# Altium schematic sheet 06 - STM32.SchDoc
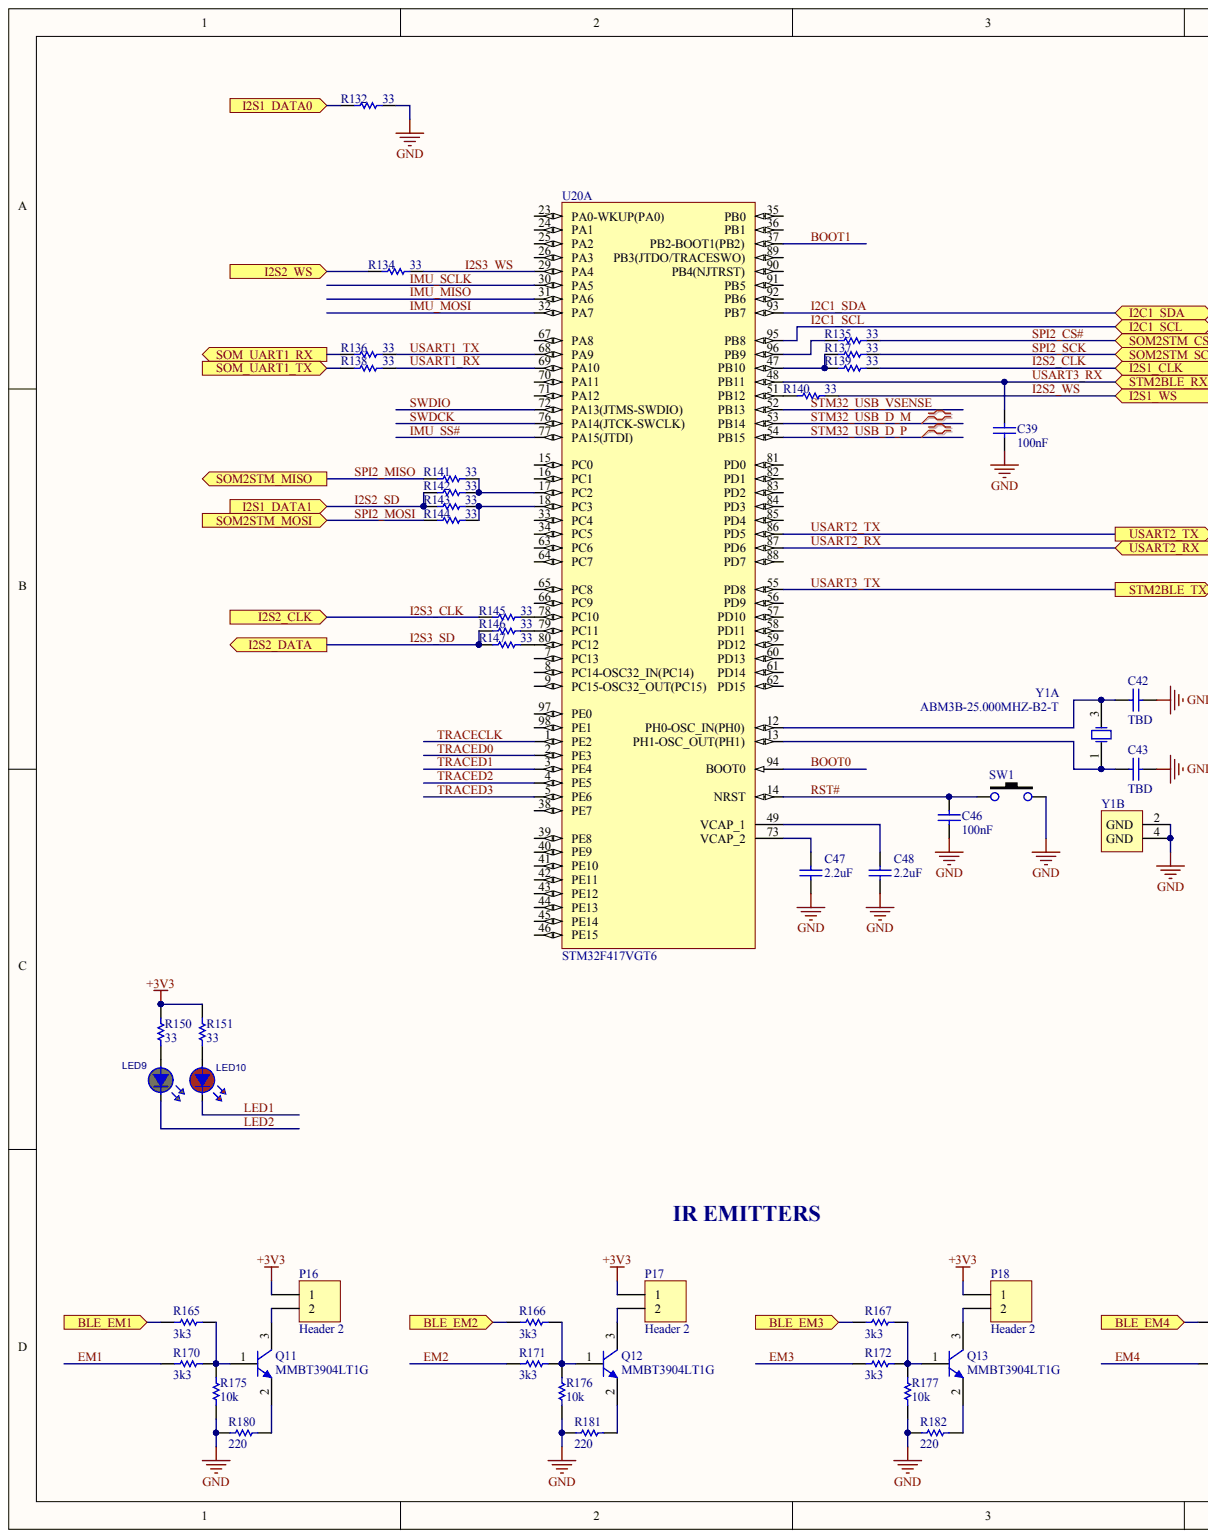
[diagram p0: 06 - STM32.SchDoc, left]
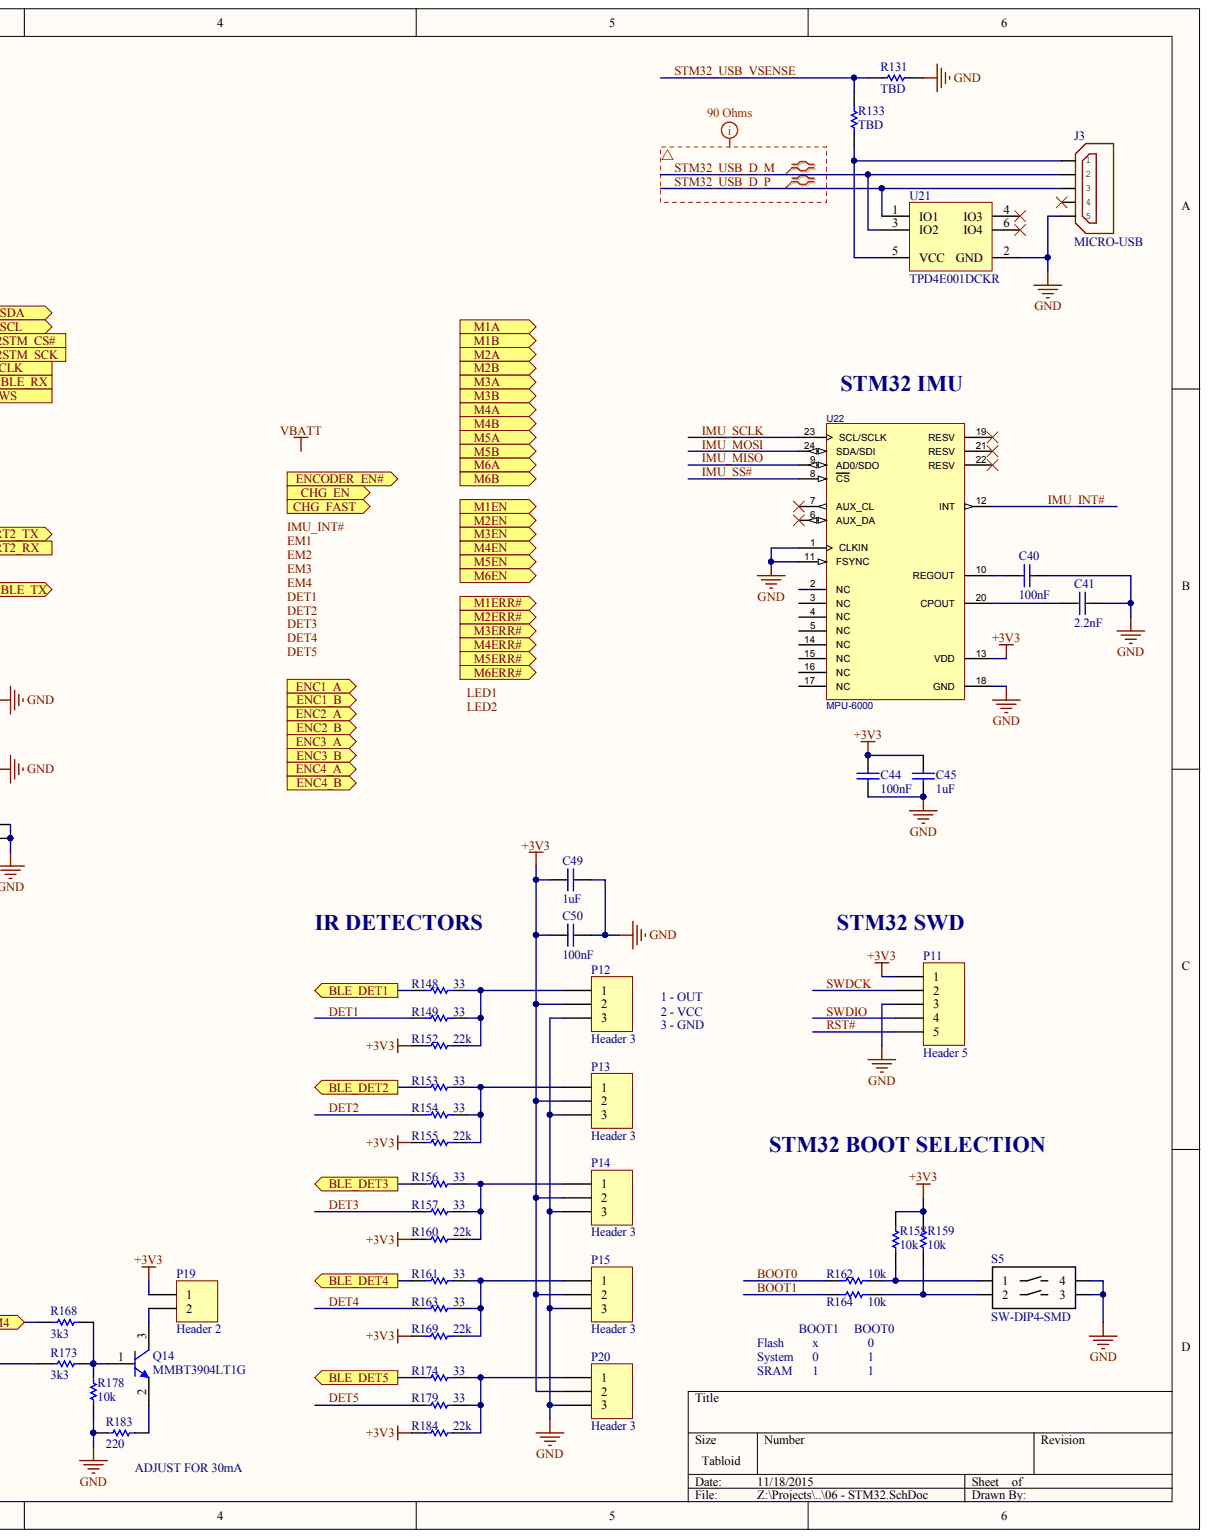
[diagram p1: 06 - STM32.SchDoc, right]

## Parts
C39  value 100nF  [CAPC0805(2012)100_N]  (hidden comment: CAP 100nF 6.3V 0805(2012))
C40  value 100nF  [CAPC0805(2012)100_N]  (hidden comment: CAP 100nF 6.3V 0805(2012))
C41  value 2.2nF  [CAPC0805(2012)100_L]
C42  value TBD  [CAPC0805(2012)100_N]
C43  value TBD  [CAPC0805(2012)100_N]
C44  value 100nF  [CAPC0805(2012)100_N]  (hidden comment: CAP 100nF 6.3V 0805(2012))
C45  value 1uF  [CAPC0805(2012)100_M]  (hidden comment: CAP 1uF 6.3V 0805(2012))
C46  value 100nF  [CAPC0805(2012)100_N]  (hidden comment: CAP 100nF 6.3V 0805(2012))
C47  value 2.2uF  [CAPC0805(2012)100_M]  (hidden comment: CAP 2.2uF 6.3V 0805(2012))
C48  value 2.2uF  [CAPC0805(2012)100_M]  (hidden comment: CAP 2.2uF 6.3V 0805(2012))
C49  value 1uF  [CAPC0805(2012)100_M]  (hidden comment: CAP 1uF 6.3V 0805(2012))
C50  value 100nF  [CAPC0805(2012)100_N]  (hidden comment: CAP 100nF 6.3V 0805(2012))
J3  MICRO-USB  [USB-MICRO]
LED9  VLMG1500-GS08  [LEDC0402(1005)_N-GRN]  (value not drawn)
LED10  VLMS1500-GS08  [LEDC0402(1005)_N-RED]  (value not drawn)
P11  Header 5  [HDR1X5]
P12  Header 3  [HDR1X3]
P13  Header 3  [HDR1X3]
P14  Header 3  [HDR1X3]
P15  Header 3  [HDR1X3]
P16  Header 2  [HDR1X2]
P17  Header 2  [HDR1X2]
P18  Header 2  [HDR1X2]
P19  Header 2  [HDR1X2]
P20  Header 3  [HDR1X3]
Q11  MMBT3904LT1G  [ONSC-SOT-23-3-318-08_V]
Q12  MMBT3904LT1G  [ONSC-SOT-23-3-318-08_V]
Q13  MMBT3904LT1G  [ONSC-SOT-23-3-318-08_V]
Q14  MMBT3904LT1G  [ONSC-SOT-23-3-318-08_V]
R131  value TBD  [RESC0805(2012)_M]
R132  value 33  [RESC0805(2012)_M]
R133  value TBD  [RESC0805(2012)_M]
R134  value 33  [RESC0805(2012)_M]
R135  value 33  [RESC0805(2012)_M]
R136  value 33  [RESC0805(2012)_M]
R137  value 33  [RESC0805(2012)_M]
R138  value 33  [RESC0805(2012)_M]
R139  value 33  [RESC0805(2012)_M]
R140  value 33  [RESC0805(2012)_M]
R141  value 33  [RESC0805(2012)_M]
R142  value 33  [RESC0805(2012)_M]
R143  value 33  [RESC0805(2012)_M]
R144  value 33  [RESC0805(2012)_M]
R145  value 33  [RESC0805(2012)_M]
R146  value 33  [RESC0805(2012)_M]
R147  value 33  [RESC0805(2012)_M]
R148  value 33  [RESC0805(2012)_M]
R149  value 33  [RESC0805(2012)_M]
R150  value 33  [RESC0805(2012)_M]
R151  value 33  [RESC0805(2012)_M]
R152  value 22k  [RESC0805(2012)_N]  (hidden comment: 22K 1% 0805(2012))
R153  value 33  [RESC0805(2012)_M]
R154  value 33  [RESC0805(2012)_M]
R155  value 22k  [RESC0805(2012)_N]  (hidden comment: 22K 1% 0805(2012))
R156  value 33  [RESC0805(2012)_M]
R157  value 33  [RESC0805(2012)_M]
R158  value 10k  [RESC0805(2012)_M]  (hidden comment: 10K 1% 0805(2012))
R159  value 10k  [RESC0805(2012)_M]  (hidden comment: 10K 1% 0805(2012))
R160  value 22k  [RESC0805(2012)_N]  (hidden comment: 22K 1% 0805(2012))
R161  value 33  [RESC0805(2012)_M]
R162  value 10k  [RESC0805(2012)_M]  (hidden comment: 10K 1% 0805(2012))
R163  value 33  [RESC0805(2012)_M]
R164  value 10k  [RESC0805(2012)_M]  (hidden comment: 10K 1% 0805(2012))
R165  value 3k3  [RESC0805(2012)_L]  (hidden comment: 3K3 1% 0805(2012))
R166  value 3k3  [RESC0805(2012)_L]  (hidden comment: 3K3 1% 0805(2012))
R167  value 3k3  [RESC0805(2012)_L]  (hidden comment: 3K3 1% 0805(2012))
R168  value 3k3  [RESC0805(2012)_L]  (hidden comment: 3K3 1% 0805(2012))
R169  value 22k  [RESC0805(2012)_N]  (hidden comment: 22K 1% 0805(2012))
R170  value 3k3  [RESC0805(2012)_L]  (hidden comment: 3K3 1% 0805(2012))
R171  value 3k3  [RESC0805(2012)_L]  (hidden comment: 3K3 1% 0805(2012))
R172  value 3k3  [RESC0805(2012)_L]  (hidden comment: 3K3 1% 0805(2012))
R173  value 3k3  [RESC0805(2012)_L]  (hidden comment: 3K3 1% 0805(2012))
R174  value 33  [RESC0805(2012)_M]
R175  value 10k  [RESC0805(2012)_M]  (hidden comment: 10K 1% 0805(2012))
R176  value 10k  [RESC0805(2012)_M]  (hidden comment: 10K 1% 0805(2012))
R177  value 10k  [RESC0805(2012)_M]  (hidden comment: 10K 1% 0805(2012))
R178  value 10k  [RESC0805(2012)_M]  (hidden comment: 10K 1% 0805(2012))
R179  value 33  [RESC0805(2012)_M]
R180  value 220  [RESC0805(2012)_M]  (hidden comment: 220R 1% 0805(2012))
R181  value 220  [RESC0805(2012)_M]  (hidden comment: 220R 1% 0805(2012))
R182  value 220  [RESC0805(2012)_M]  (hidden comment: 220R 1% 0805(2012))
R183  value 220  [RESC0805(2012)_M]  (hidden comment: 220R 1% 0805(2012))
R184  value 22k  [RESC0805(2012)_N]  (hidden comment: 22K 1% 0805(2012))
S5  SW-DIP4-SMD  [SO4SW]
SW1  SW-PB6MM-SMD  [SW-PB-SMD]  (value not drawn)
U20  STM32F417VGT6  [STM-LQFP100_M]  (part A)
U21  TPD4E001DCKR  [DCK0006A_M]
U22  MPU-6000  [QFN-ML24_N_NOPAD]
Y1  ABM3B-25.000MHZ-B2-T  [ABRA-ABM3B-4_V]  (parts A,B)

## Ports
BLE_DET1, BLE_DET2, BLE_DET3, BLE_DET4, BLE_DET5, BLE_EM1, BLE_EM2, BLE_EM3, BLE_EM4, CHG_EN, CHG_FAST, ENC1_A, ENC1_B, ENC2_A, ENC2_B, ENC3_A, ENC3_B, ENC4_A, ENC4_B, ENCODER_EN#, I2C1_SCL, I2C1_SDA, I2S1_CLK, I2S1_DATA0, I2S1_DATA1, I2S1_WS, I2S2_CLK, I2S2_DATA, I2S2_WS, M1A, M1B, M1EN, M1ERR#, M2A, M2B, M2EN, M2ERR#, M3A, M3B, M3EN, M3ERR#, M4A, M4B, M4EN, M4ERR#, M5A, M5B, M5EN, M5ERR#, M6A, M6B, M6EN, M6ERR#, SOM2STM_CS#, SOM2STM_MISO, SOM2STM_MOSI, SOM2STM_SCK, SOM_UART1_RX, SOM_UART1_TX, STM2BLE_RX, STM2BLE_TX, USART2_RX, USART2_TX

## Nets
+3V3: C44.1 C45.1 C49.1 C50.1 P11.1 P12.2 P13.2 P14.2 P15.2 P16.1 P17.1 P18.1 P19.1 P20.2 R150.1 R151.1 R152.2 R155.2 R158.1 R159.1 R160.2 R169.2 R184.2 U22.13(VDD)
GND: C39.2 C40.2 C41.2 C42.2 C43.2 C44.2 C45.2 C46.2 C47.1 C48.1 C49.2 C50.2 J3.ID P11.3 P12.3 P13.3 P14.3 P15.3 P20.3 R131.2 R132.1 R175.1 R176.1 R177.1 R178.1 R180.2 R181.2 R182.2 R183.2 S5.3 S5.4 SW1.2 U21.2(GND) U22.1(CLKIN) U22.11(FSYNC) U22.18(GND) Y1.2(GND) Y1.4(GND)
BLE_DET1: R148.2 ; port BLE_DET1
BLE_DET2: R153.2 ; port BLE_DET2
BLE_DET3: R156.2 ; port BLE_DET3
BLE_DET4: R161.2 ; port BLE_DET4
BLE_DET5: R174.2 ; port BLE_DET5
BLE_EM1: R165.1 ; port BLE_EM1
BLE_EM2: R166.1 ; port BLE_EM2
BLE_EM3: R167.1 ; port BLE_EM3
BLE_EM4: R168.1 ; port BLE_EM4
BOOT0: R162.2 U20.94(BOOT0)
BOOT1: R164.2 U20.37(PB2-BOOT1(PB2))
DET1: R149.2
DET2: R154.2
DET3: R157.2
DET4: R163.2
DET5: R179.2
EM1: R170.1
EM2: R171.1
EM3: R172.1
EM4: R173.1
I2C1_SCL: U20.95(PB8) ; port I2C1_SCL
I2C1_SDA: U20.93(PB7) ; port I2C1_SDA
I2S1_DATA0: R132.2 ; port I2S1_DATA0
I2S2_CLK: R139.1 ; port I2S1_CLK
I2S2_SD: R142.2 R143.2 ; port I2S1_DATA1
I2S2_WS: R140.1 ; port I2S1_WS
I2S3_CLK: R145.2 ; port I2S2_CLK
I2S3_SD: R146.2 R147.2 ; port I2S2_DATA
I2S3_WS: R134.1 U20.29(PA4)
IMU_INT#: U22.12(INT)
IMU_MISO: U20.31(PA6) U22.9(AD0/SDO)
IMU_MOSI: U20.32(PA7) U22.24(SDA/SDI)
IMU_SCLK: U20.30(PA5) U22.23(SCL/SCLK)
IMU_SS#: U20.77(PA15(JTDI)) U22.8(CS)
LED1: LED10.K
LED2: LED9.K
RST#: C46.1 P11.5 SW1.1 U20.14(NRST)
SOM_UART1_RX: R136.2 ; port SOM_UART1_RX
SOM_UART1_TX: R138.2 ; port SOM_UART1_TX
SPI2_CS#: R135.1 ; port SOM2STM_CS#
SPI2_MISO: R141.2 ; port SOM2STM_MISO
SPI2_MOSI: R144.2 ; port SOM2STM_MOSI
SPI2_SCK: R137.1 ; port SOM2STM_SCK
STM32_USB_D_M: J3.D- U20.53(PB14) U21.3(IO2)
STM32_USB_D_P: J3.D+ U20.54(PB15) U21.1(IO1)
STM32_USB_VSENSE: R131.1 R133.1 U20.52(PB13)
SWDCK: P11.2 U20.76(PA14(JTCK-SWCLK))
SWDIO: P11.4 U20.72(PA13(JTMS-SWDIO))
TRACECLK: U20.1(PE2)
TRACED0: U20.2(PE3)
TRACED1: U20.3(PE4)
TRACED2: U20.4(PE5)
TRACED3: U20.5(PE6)
USART1_RX: R138.1 U20.69(PA10)
USART1_TX: R136.1 U20.68(PA9)
USART2_RX: U20.87(PD6) ; port USART2_RX
USART2_TX: U20.86(PD5) ; port USART2_TX
USART3_RX: C39.1 U20.48(PB11) ; port STM2BLE_RX
USART3_TX: U20.55(PD8) ; port STM2BLE_TX
NetC40_1: C40.1 U22.10(REGOUT)
NetC41_1: C41.1 U22.20(CPOUT)
NetC42_1: C42.1 U20.12(PH0-OSC_IN(PH0)) Y1.3
NetC43_1: C43.1 U20.13(PH1-OSC_OUT(PH1)) Y1.1
NetC47_2: C47.2 U20.73(VCAP_2)
NetC48_2: C48.2 U20.49(VCAP_1)
NetJ3_VBUS: J3.VBUS R133.2 U21.5(VCC)
NetLED9_A: LED9.A R150.2
NetLED10_A: LED10.A R151.2
NetP12_1: P12.1 R148.1 R149.1 R152.1
NetP13_1: P13.1 R153.1 R154.1 R155.1
NetP14_1: P14.1 R156.1 R157.1 R160.1
NetP15_1: P15.1 R161.1 R163.1 R169.1
NetP16_2: P16.2 Q11.3
NetP17_2: P17.2 Q12.3
NetP18_2: P18.2 Q13.3
NetP19_2: P19.2 Q14.3
NetP20_1: P20.1 R174.1 R179.1 R184.1
NetQ11_1: Q11.1 R165.2 R170.2 R175.2
NetQ11_2: Q11.2 R180.1
NetQ12_1: Q12.1 R166.2 R171.2 R176.2
NetQ12_2: Q12.2 R181.1
NetQ13_1: Q13.1 R167.2 R172.2 R177.2
NetQ13_2: Q13.2 R182.1
NetQ14_1: Q14.1 R168.2 R173.2 R178.2
NetQ14_2: Q14.2 R183.1
NetR134_2: R134.2 ; port I2S2_WS
NetR135_2: R135.2 U20.96(PB9)
NetR137_2: R137.2 R139.2 U20.47(PB10)
NetR140_2: R140.2 U20.51(PB12)
NetR141_1: R141.1 R142.1 U20.17(PC2)
NetR143_1: R143.1 R144.1 U20.18(PC3)
NetR145_1: R145.1 U20.78(PC10)
NetR146_1: R146.1 U20.79(PC11)
NetR147_1: R147.1 U20.80(PC12)
NetR158_2: R158.2 R162.1 S5.1
NetR159_2: R159.2 R164.1 S5.2
N00416:
N00417: ; port M6EN
N00418: ; port M6ERR#
N00419: ; port M6B
N00420: ; port M6A
N00421: ; port M5EN
N00422: ; port M5ERR#
N00423: ; port M5B
N00424: ; port M5A
N00425: ; port M4EN
N00426: ; port M4ERR#
N00427: ; port M4B
N00428: ; port M4A
N00429: ; port M1EN
N00430: ; port M1ERR#
N00431: ; port M1B
N00432: ; port M1A
N00433: ; port M3EN
N00434: ; port M3ERR#
N00435: ; port M3B
N00436: ; port M3A
N00437: ; port M2EN
N00438: ; port M2ERR#
N00439: ; port M2B
N00440: ; port M2A
N00441: ; port ENC4_B
N00442: ; port ENC4_A
N00443: ; port ENC3_B
N00444: ; port ENC3_A
N00445: ; port ENC2_B
N00446: ; port ENC2_A
N00447: ; port ENC1_B
N00448: ; port ENC1_A
N00449: ; port CHG_FAST
N00450: ; port CHG_EN
N00451: ; port ENCODER_EN#
VBATT:

Not connected: J3 GND; U20 7(PC13) 8(PC14-OSC32_IN(PC14)) 9(PC15-OSC32_OUT(PC15)) 15(PC0) 16(PC1) 23(PA0-WKUP(PA0)) 24(PA1) 25(PA2) 26(PA3) 33(PC4) 34(PC5) 35(PB0) 36(PB1) 38(PE7) 39(PE8) 40(PE9) 41(PE10) 42(PE11) 43(PE12) 44(PE13) 45(PE14) 46(PE15) 56(PD9) 57(PD10) 58(PD11) 59(PD12) 60(PD13) 61(PD14) 62(PD15) 63(PC6) 64(PC7) 65(PC8) 66(PC9) 67(PA8) 70(PA11) 71(PA12) 81(PD0) 82(PD1) 83(PD2) 84(PD3) 85(PD4) 88(PD7) 89(PB3(JTDO/TRACESWO)) 90(PB4(NJTRST)) 91(PB5) 92(PB6) 97(PE0) 98(PE1); U21 4(IO3) 6(IO4); U22 2(NC) 3(NC) 4(NC) 5(NC) 6(AUX_DA) 7(AUX_CL) 14(NC) 15(NC) 16(NC) 17(NC) 19(RESV) 21(RESV) 22(RESV)

## Library data of the parts
C39 C40 C42-C44 C46 C50 = CMP-1036-04391-1: CAP 100nF 6.3V Â±10% 0805 (2012 Metric) Thickness 1mm SMD; Case-EIA: 0805; Case-Metric: 2012; Component Kind: Standard; Library Name: Altium Content Vault; Max Thickness: 1 mm; Rated Voltage: 6.3 V; Technology: SMT; Tolerance: Â±10%
C41 = CMP-1036-04520-1: CAP 220nF 6.3V Â±20% 0805 (2012 Metric) Thickness 1mm SMD; Case-EIA: 0805; Case-Metric: 2012; Component Kind: Standard; Library Name: Altium Content Vault; Max Thickness: 1 mm; Rated Voltage: 6.3 V; Technology: SMT; Tolerance: Â±20%
C45 C49 = CMP-1036-04730-1: CAP 1uF 6.3V Â±5% 0805 (2012 Metric) Thickness 1mm SMD; Case-EIA: 0805; Case-Metric: 2012; Component Kind: Standard; Library Name: Altium Content Vault; Max Thickness: 1 mm; Rated Voltage: 6.3 V; Technology: SMT; Tolerance: Â±5%
C47 C48 = CMP-1036-04821-1: CAP 2.2uF 6.3V Â±20% 0805 (2012 Metric) Thickness 1mm SMD; Case-EIA: 0805; Case-Metric: 2012; Component Kind: Standard; Library Name: Altium Content Vault; Max Thickness: 1 mm; Rated Voltage: 6.3 V; Technology: SMT; Tolerance: Â±20%
LED9 = LED_0402_VLMG1500-GS08: LED GREEN 0402 SMD; Manufacturer: Vishay Semiconductor Opto Division; Current - Test: 20mA; Lens Style/Size: Rectangle with Flat Top, 1.00mm x 0.50mm; Millicandela Rating: 35mcd; Viewing Angle: 130°; Voltage - Forward (Vf) (Typ): 2V; Wavelength - Dominant: 571nm; Wavelength - Peak: 574nm; Category: Optoelectronics; Color: Green; Component Kind: Standard; ComponentLink1Description: http://www.vishay.com/docs/82554/vlmo1500.pdf; ComponentLink1URL: http://www.vishay.com/docs/82554/vlmo1500.pdf; Height (Max): 0.35mm; Lens Color: -; Lens Transparency: -; Library Name: RevAir32.IntLib; Manufacturer Part Number: VLMG1500-GS08; Mounting Type: Surface Mount; Package / Case: 0402 (1005 Metric); Packaging: Cut Tape (CT); Pricing 1: 1=0.52, 10=0.384, 25=0.3072, 100=0.2035, 250=0.144, 500=0.12288, 750=0.10368, 1000=0.096 (USD); RoHS: RoHS Compliant; Size / Dimension: 1.00mm L x 0.50mm W; Stock 1: 37065; Supplier 1: Digi-Key; Supplier Device Package: 0402; Supplier Part Number 1: VLMG1500-GS08CT-ND
LED10 = LED_0402_VLMS1500-GS08: LED RED 0402 SMD; Manufacturer: Vishay Semiconductor Opto Division; Current - Test: 20mA; Lens Style/Size: Rectangle with Flat Top, 1.00mm x 0.50mm; Millicandela Rating: 54mcd; Viewing Angle: 130°; Voltage - Forward (Vf) (Typ): 2V; Wavelength - Dominant: 631nm; Wavelength - Peak: 639nm; Category: Optoelectronics; Color: Red; Component Kind: Standard; ComponentLink1Description: http://www.vishay.com/docs/82554/vlmo1500.pdf; ComponentLink1URL: http://www.vishay.com/docs/82554/vlmo1500.pdf; Height (Max): 0.35mm; Lens Color: -; Lens Transparency: -; Library Name: RevAir32.IntLib; Manufacturer Part Number: VLMS1500-GS08; Mounting Type: Surface Mount; Package / Case: 0402 (1005 Metric); Packaging: Cut Tape (CT); Pricing 1: 1=0.52, 10=0.384, 25=0.3072, 100=0.2035, 250=0.144, 500=0.12288, 750=0.10368, 1000=0.096 (USD); RoHS: RoHS Compliant; Size / Dimension: 1.00mm L x 0.50mm W; Stock 1: 41406; Supplier 1: Digi-Key; Supplier Device Package: 0402; Supplier Part Number 1: VLMS1500-GS08CT-ND
P11 = Header 5: Header, 5-Pin; Component Kind: Standard; LatestRevisionDate: 17-Jul-2002; LatestRevisionNote: Re-released for DXP Platform.; Library Name: Miscellaneous Connectors.IntLib; Signal Integrity: Connector; Publisher: Altium Limited
P12-P15 P20 = Header 3: Header, 3-Pin; Component Kind: Standard; LatestRevisionDate: 17-Jul-2002; LatestRevisionNote: Re-released for DXP Platform.; Library Name: Miscellaneous Connectors.IntLib; Signal Integrity: Connector; Publisher: Altium Limited
P16-P19 = Header 2: Header, 2-Pin; Component Kind: Standard; LatestRevisionDate: 17-Jul-2002; LatestRevisionNote: Re-released for DXP Platform.; Library Name: Miscellaneous Connectors.IntLib; Signal Integrity: Connector; Publisher: Altium Limited
Q11-Q14 = CMP-1048-00631-1: General Purpose Transistor, NPN Silicon, 3-Pin SOT-23, Pb-Free, Tape and Reel; Manufacturer: On Semiconductor; fT Min (MHz): 300; IC Continuous (A): 0.2; Component Kind: Standard; ComponentLink1Description: Manufacturer URL; ComponentLink1URL: http://www.onsemi.com/; ComponentLink2Description: Datasheet; ComponentLink2URL: http://www.onsemi.cn/pub_link/Collateral/MMBT3904LT1-D.PDF; ComponentLink3Description: Package Specification; ComponentLink3URL: http://www.onsemi.cn/pub_link/Collateral/318-08.PDF; DatasheetVersion: Rev. 12; Library Name: Altium Content Vault; Mounting Technology: Surface Mount; PackageDescription: 3-Pin Small Outline Transistor, Body 2.92 x 1.3 mm, Pitch 0.95 mm; PackageReference: SOT-23-3-318-08; PackageVersion: Rev. AP, 11/2009; Packing: Tape and Reel; PartNumber: MMBT3904LT1G; Polarity: NPN; RoHS: TRUE
R131-R151 R153 R154 R156-R159 R161-R164 R174-R179 = CMP-1013-00623-1: 10K 0.125W 1% 0805 (2012 Metric)  SMD; Case-EIA: 0805; Case-Metric: 2012; Component Kind: Standard; Power: 0.125 W; Technology: SMT; Tolerance: 1 %
  R131-R151 R153 R154 R156-R159 R161-R164 R174 R179: Library Name: Altium Inc., Content Vault
  R175-R178: Library Name: Altium Content Vault
R152 R155 R160 R169 R184 = CMP-1013-00659-1: 22K 0.125W 1% 0805 (2012 Metric)  SMD; Case-EIA: 0805; Case-Metric: 2012; Component Kind: Standard; Library Name: Altium Content Vault; Power: 0.125 W; Technology: SMT; Tolerance: 1 %
R165-R168 R170-R173 = CMP-1013-00567-1: 3K3 0.125W 1% 0805 (2012 Metric)  SMD; Case-EIA: 0805; Case-Metric: 2012; Component Kind: Standard; Library Name: Altium Content Vault; Power: 0.125 W; Technology: SMT; Tolerance: 1 %
R180-R183 = CMP-1013-00433-1: 220R 0.125W 1% 0805 (2012 Metric)  SMD; Case-EIA: 0805; Case-Metric: 2012; Component Kind: Standard; Library Name: Altium Content Vault; Power: 0.125 W; Technology: SMT; Tolerance: 1 %
S5 = SW-DIP4-SMD: 2 POLE DIP SWITCH SMD; Component Kind: Standard; Library Name: vh_altium_library.intLib
SW1 = SW-PB6MM-SMD: PUSHBUTTON SWITCH 6MM SMD; Component Kind: Standard; Library Name: vh_altium_library.intLib
U20 = CMP-0243-00014-3: ARM Cortex-M4 32-bit MCU+FPU, 210 DMIPS, 1024 kB Flash, 192 kB Internal RAM, 82 I/Os, 100-pin LQFP, -40 to 85 degC, Tray; Manufacturer: STMicroelectronics; D/A Converter: 2x12-bit; FLASH Size (Prog) (kB): 1024; I/Os (High Current): 82; Internal RAM Size (kB): 192; Operating Frequency(F) (Processor speed) (MHz): 168; Supply Voltage(Vcc) max (V): 3.6; Supply Voltage(Vcc) min (V): 1.8; Component Kind: Standard; ComponentLink1Description: Manufacturer URL; ComponentLink1URL: http://www.st.com/web/en/home.html; ComponentLink2Description: Datasheet; ComponentLink2URL: http://www.st.com/st-web-ui/static/active/en/resource/technical/document/datasheet/DM00035129.pdf; ComponentLink3Description: Package Specification; ComponentLink3URL: http://www.st.com/st-web-ui/static/active/en/resource/technical/document/data_brief/DM00071938.pdf; Core: ARM Cortex-M4; DatasheetVersion: Rev. 4; Library Name: Altium Content Vault; Mounting Technology: Surface Mount; PackageDescription: 100-Pin Low Profile Quad Flat Package, 14 x 14 mm Body, 0.5 mm Pitch; PackageReference: LQFP100; PackageVersion: Rev. 1, 06/2013; Packing: Tray; PartNumber: STM32F417VGT6
U21 = CMP-0326-00066-2: Low-Capacitance + / - 15-kV ESD Protection Array for High-Speed Data Interfaces, 4 Channels, -40 to +85 degC, 6-pin SOT70 (DCK), Green (RoHS & no Sb/Br); Manufacturer: Texas Instruments; 1394 / Firewire: TRUE; Breakdown Voltage(Min)(V): 11; IEC 61000-4-2 Air-Gap(+/- kV): 15; IEC 61000-4-2 Contact(+/- kV): 8; IO Capacitance(Typ)(pF): 1.5; SubFamily: ESD Solution; Component Kind: Standard; ComponentLink1Description: Manufacturer URL; ComponentLink1URL: http://www.ti.com/; ComponentLink2Description: Datasheet; ComponentLink2URL: http://www.ti.com/general/docs/lit/getliterature.tsp?genericPartNumber=TPD4E001&fileType=pdf; ComponentLink3Description: URL Link1; ComponentLink3URL: SamplesURL:http://www.ti.com/product/tpd4e001#samples; DatasheetVersion: SLLS682G; Eco-Plan: Green (RoHS & no Sb/Br); IO Leakage Current(nA): 1.5; Library Name: Altium Inc., Content Vault; Mounting Technology: Surface Mount; PackageDescription: 6-Pin Plastic Small-Outline Package, 2 x 1.3 mm Body, 0.65 mm Pitch, 2.1 mm Lead Span; PackageReference: DCK0006A; PackageVersion: revG, Jan-2007; PartNumber: TPD4E001DCKR; Serial ATA / eSATA: TRUE; USB High Speed: TRUE
U22 = IC_MPU-6000: GYRO/ACCELEROMETER 6AXIS 24QFN I2C/SPI; Manufacturer: InvenSense; Output Type: I²C, SPI; Sensor Type: Accelerometer, Gyroscope, 3 Axis; Category: Sensors, Transducers; Component Kind: Standard; ComponentLink1Description: http://store.invensense.com/datasheets/invensense/MPU-6050_DataSheet_V3%204.pdf; ComponentLink1URL: http://store.invensense.com/datasheets/invensense/MPU-6050_DataSheet_V3%204.pdf; Library Name: RevAir32.IntLib; Manufacturer Part Number: MPU-6000; Pricing 1: 1=10.72, 5=9.406, 10=8.465, 25=7.524, 50=6.9598, 100=6.0192, 250=5.83112, 500=5.643, 750=5.45491 (USD); RoHS: RoHS Compliant; Stock 1: 27337; Supplier 1: Digi-Key; Supplier Part Number 1: 1428-1005-1-ND
Y1 = CMP-0277-00001-1: Crystal, SMD, 25.000000MHz Stab=50ppm 18.0pF; Manufacturer: Abracon; Body Shape: Rectangular; Packing Type: Tape & Reel; Case Size: 5.0 x 3.2 mm; Component Kind: Standard; ComponentLink1Description: Manufacturer URL; ComponentLink1URL: http://www.abracon.com/; ComponentLink2Description: Datasheet; ComponentLink2URL: http://www.abracon.com/Resonators/abm3b.pdf; DatasheetVersion: Apr-13; Library Name: Altium Inc., Content Vault; Mounting Technology: Surface Mount; PackageDescription: 4-Pin SMT Crystal, 5.2 x 3.4 mm Body; PackageReference: ABM3B; PartNumber: ABM3B-25.000MHZ-B2-T; Storage Temperature: -40 to 80 degC
J3 = MICRO-USB: Component Kind: Standard; Library Name: ak-misc.IntLib
